# Altium schematic sheet 20 PCIe.SchDoc
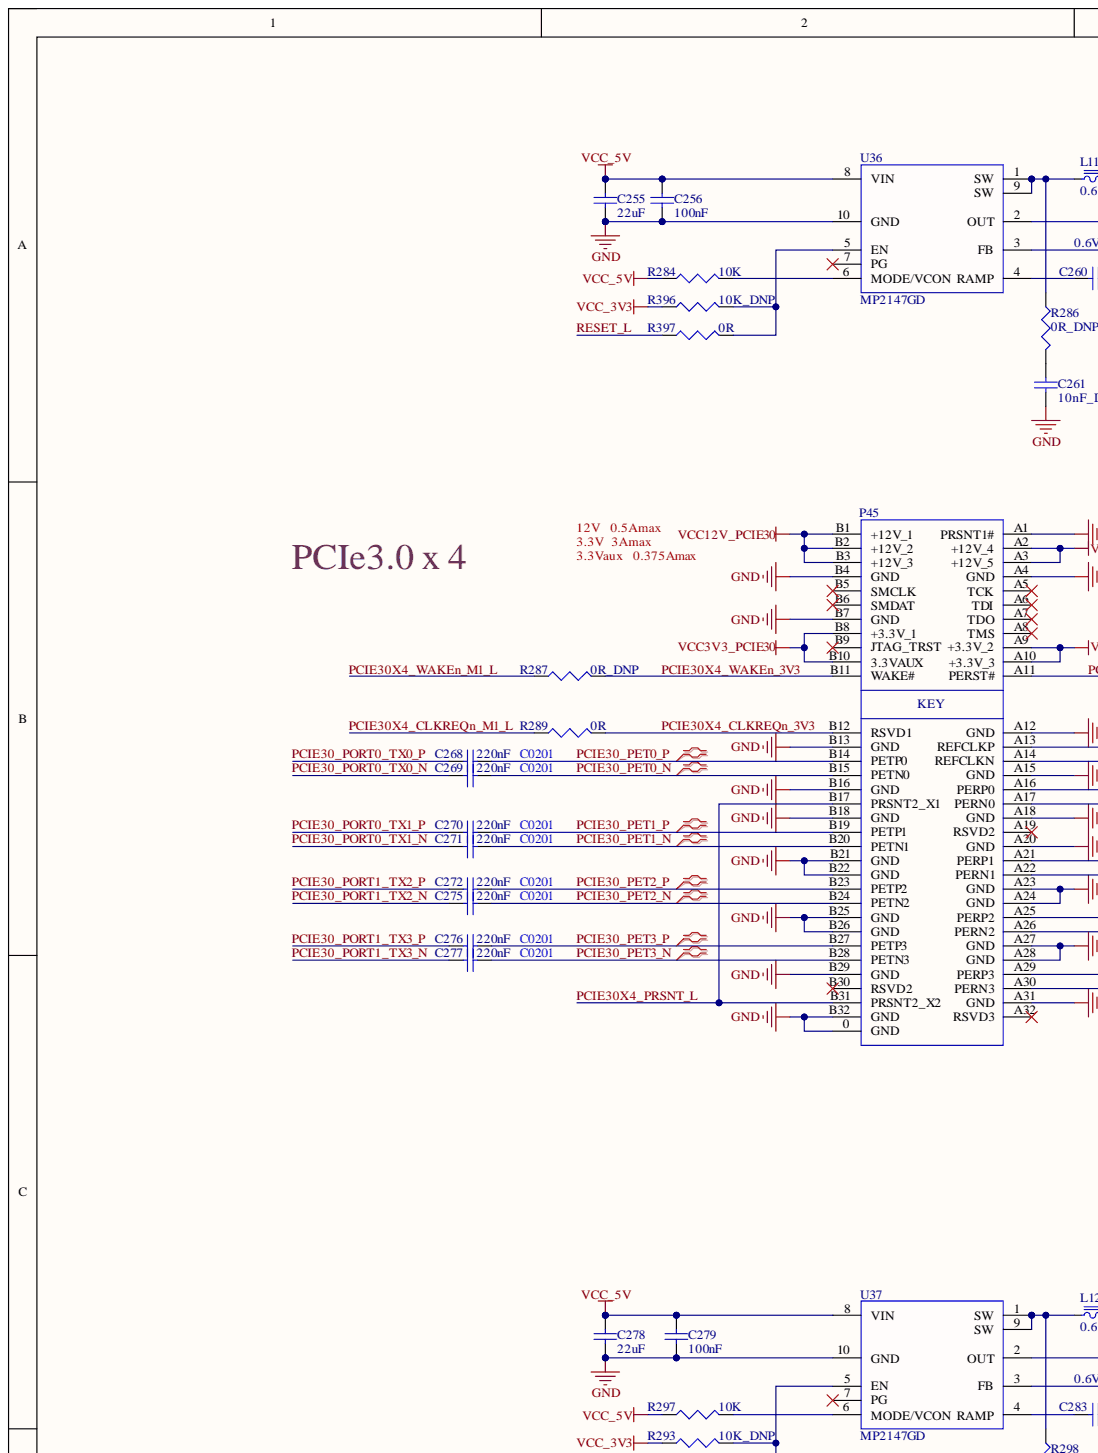
[diagram p0: 20 PCIe.SchDoc, top left]
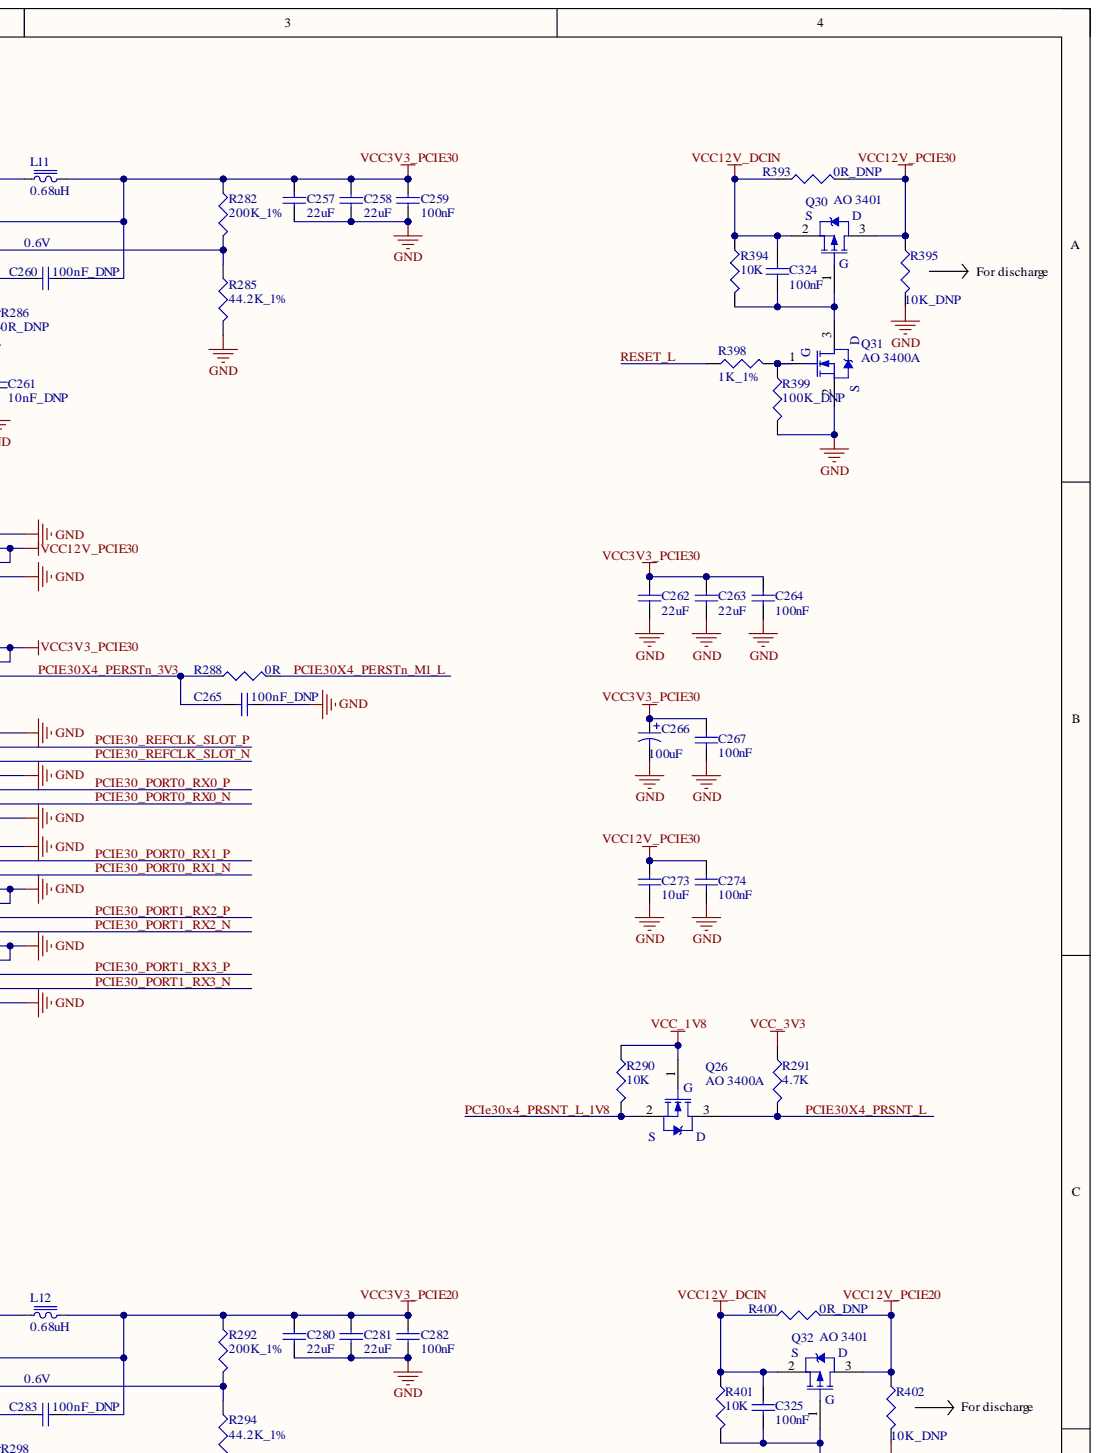
[diagram p1: 20 PCIe.SchDoc, top right]
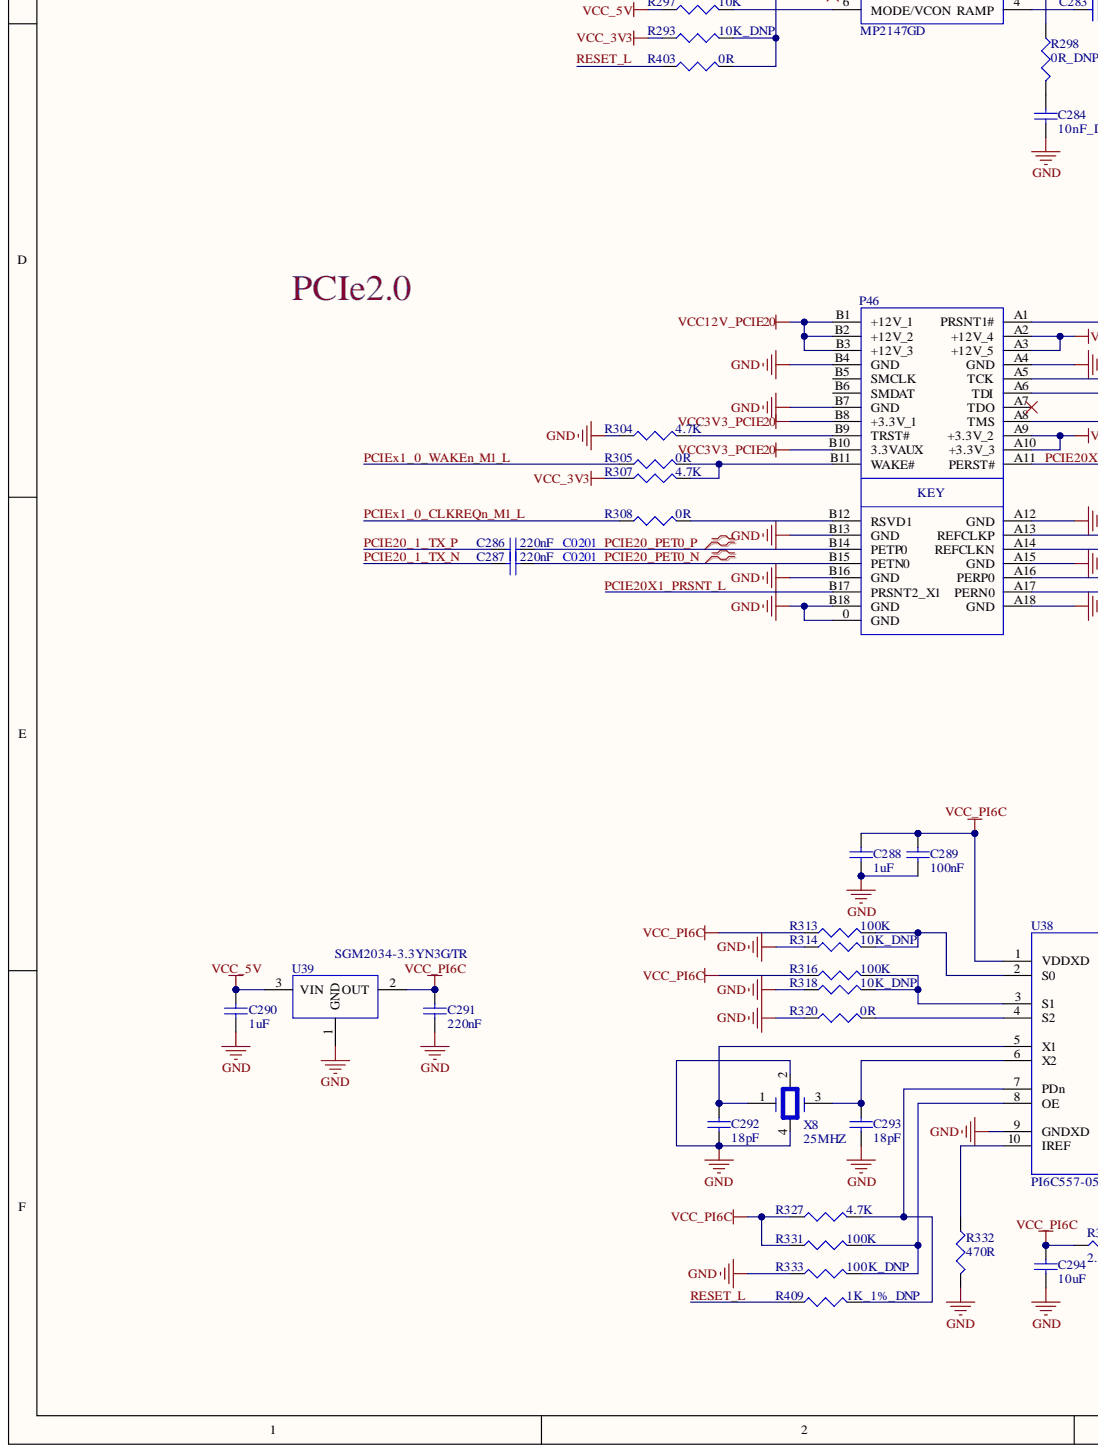
[diagram p2: 20 PCIe.SchDoc, bottom left]
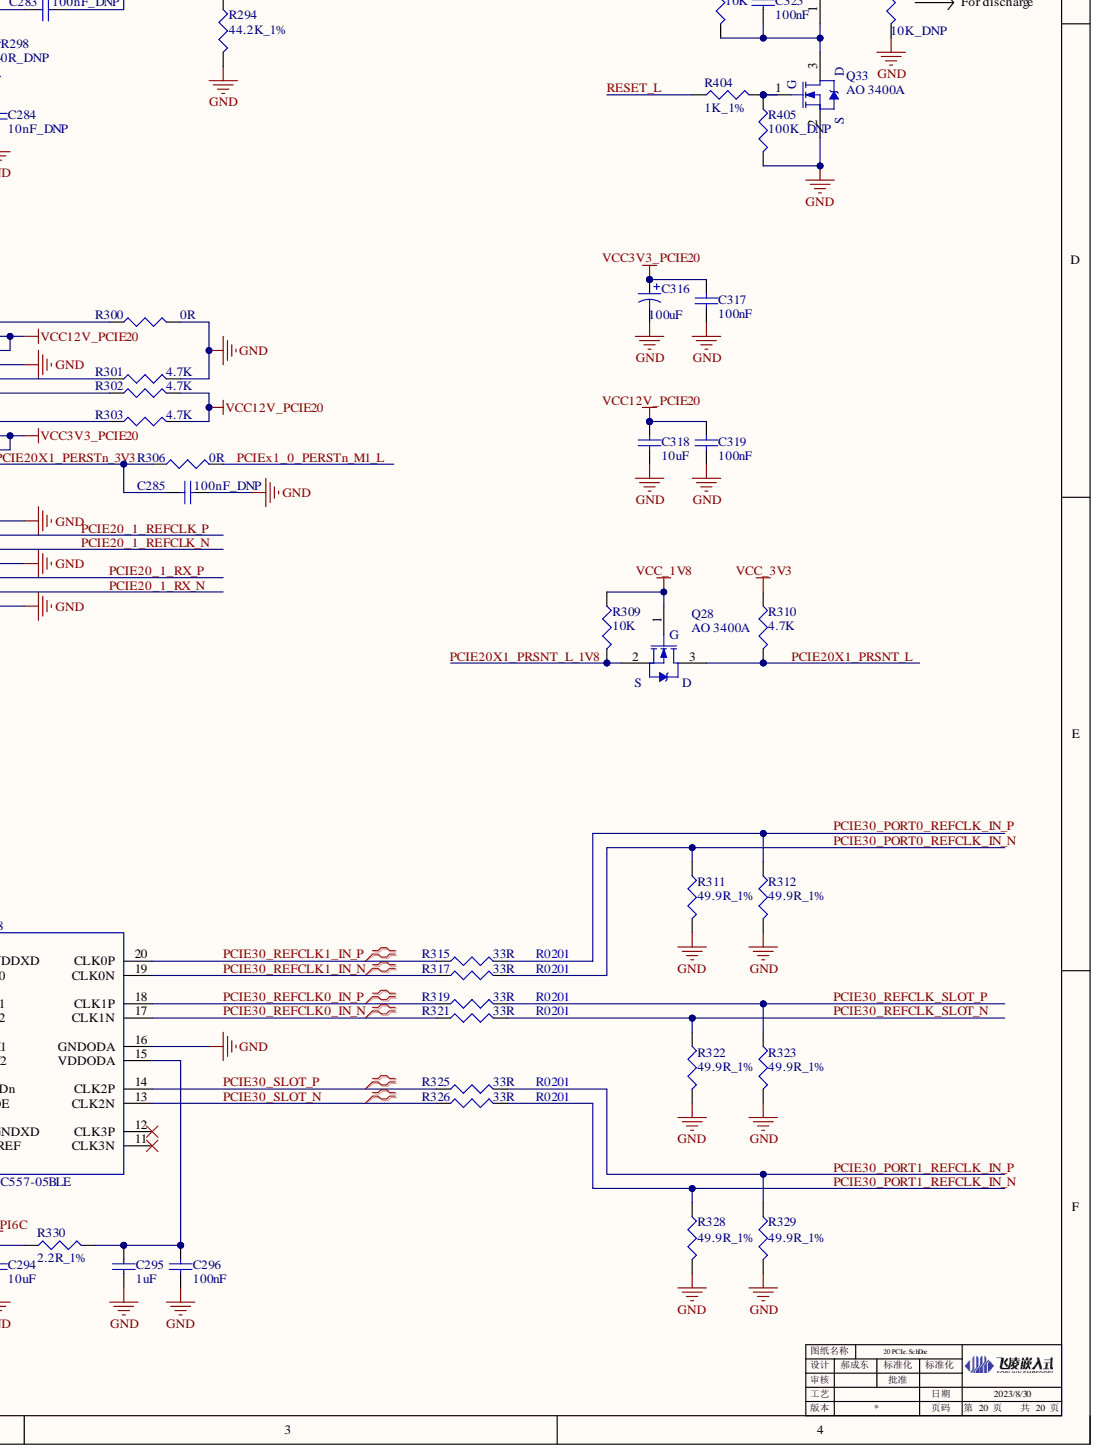
[diagram p3: 20 PCIe.SchDoc, bottom right]

## Parts
C255  22uF  [0805C]
C256  100nF  [0402C]
C257  22uF  [0805C]
C258  22uF  [0805C]
C259  100nF  [0402C]
C260  100nF_DNP  [0402C]
C261  10nF_DNP  [0402C]
C262  22uF  [0603C]
C263  22uF  [0603C]
C264  100nF  [0402C]
C265  100nF_DNP  [0402C]
C266  100uF  [CAP SMT_6.3x7.7]
C267  100nF  [0402C]
C268  220nF  [0201C]
C269  220nF  [0201C]
C270  220nF  [0201C]
C271  220nF  [0201C]
C272  220nF  [0201C]
C273  10uF  [1206C]
C274  100nF  [0402C]
C275  220nF  [0201C]
C276  220nF  [0201C]
C277  220nF  [0201C]
C278  22uF  [0805C]
C279  100nF  [0402C]
C280  22uF  [0805C]
C281  22uF  [0805C]
C282  100nF  [0402C]
C283  100nF_DNP  [0402C]
C284  10nF_DNP  [0402C]
C285  100nF_DNP  [0402C]
C286  220nF  [0201C]
C287  220nF  [0201C]
C288  1uF  [0402C]
C289  100nF  [0402C]
C290  1uF  [0402C]
C291  220nF  [0402C]
C292  18pF  [0402C]
C293  18pF  [0402C]
C294  10uF  [0402C]
C295  1uF  [0402C]
C296  100nF  [0402C]
C316  100uF  [CAP SMT_6.3x7.7]
C317  100nF  [0402C]
C318  10uF  [1206C]
C319  100nF  [0402C]
C324  100nF  [0402C]
C325  100nF  [0402C]
L11  0.68uH  [WPN4020H]
L12  0.68uH  [WPN4020H]
P45  (no value)  [PCIE_X4_SMT]
P46  (no value)  [PCIE_X1_SMT]
Q26  AO 3400A  [SOT_233_W]
Q28  AO 3400A  [SOT_233_W]
Q30  AO 3401  [SOT_233_W]
Q31  AO 3400A  [SOT_233_W]
Q32  AO 3401  [SOT_233_W]
Q33  AO 3400A  [SOT_233_W]
R282  200K_1%  [0402R]
R284  10K  [0402R]
R285  44.2K_1%  [0402R]
R286  0R_DNP  [0402R]
R287  0R_DNP  [0402R]
R288  0R  [0402R]
R289  0R  [0402R]
R290  10K  [0402R]
R291  4.7K  [0402R]
R292  200K_1%  [0402R]
R293  10K_DNP  [0402R]
R294  44.2K_1%  [0402R]
R297  10K  [0402R]
R298  0R_DNP  [0402R]
R300  0R  [0402R]
R301  4.7K  [0402R]
R302  4.7K  [0402R]
R303  4.7K  [0402R]
R304  4.7K  [0402R]
R305  0R  [0402R]
R306  0R  [0402R]
R307  4.7K  [0402R]
R308  0R  [0402R]
R309  10K  [0402R]
R310  4.7K  [0402R]
R311  49.9R_1%  [0201R]
R312  49.9R_1%  [0201R]
R313  100K  [0402R]
R314  10K_DNP  [0402R]
R315  33R  [0201R]
R316  100K  [0402R]
R317  33R  [0201R]
R318  10K_DNP  [0402R]
R319  33R  [0201R]
R320  0R  [0402R]
R321  33R  [0201R]
R322  49.9R_1%  [0201R]
R323  49.9R_1%  [0201R]
R325  33R  [0201R]
R326  33R  [0201R]
R327  4.7K  [0402R]
R328  49.9R_1%  [0201R]
R329  49.9R_1%  [0201R]
R330  2.2R_1%  [0402R]
R331  100K  [0402R]
R332  470R  [0402R]
R333  100K_DNP  [0402R]
R393  0R_DNP  [0402R]
R394  10K  [0402R]
R395  10K_DNP  [0402R]
R396  10K_DNP  [0402R]
R397  0R  [0402R]
R398  1K_1%  [0402R]
R399  100K_DNP  [0402R]
R400  0R_DNP  [0402R]
R401  10K  [0402R]
R402  10K_DNP  [0402R]
R403  0R  [0402R]
R404  1K_1%  [0402R]
R405  100K_DNP  [0402R]
R409  1K_1%_DNP  [0402R]
U36  MP2147GD  [QFN_2*3]
U37  MP2147GD  [QFN_2*3]
U38  PI6C557-05BLE  [TSSOP_20]
U39  SGM2034-3.3YN3G/TR  [SOT_233_N]
X8  25MHZ  [Crystals_3225]

## Nets
GND: C255.1 C256.1 C257.1 C258.1 C259.1 C261.1 C262.1 C263.1 C264.1 C265.2 C266.2 C267.1 C273.2 C274.1 C278.1 C279.1 C280.1 C281.1 C282.1 C284.1 C285.2 C288.1 C289.1 C290.1 C291.1 C292.1 C293.1 C294.1 C295.1 C296.1 C316.2 C317.1 C318.2 C319.1 P45.0(GND) P45.A1(PRSNT1#) P45.A4(GND) P45.A12(GND) P45.A15(GND) P45.A18(GND) P45.A20(GND) P45.A23(GND) P45.A24(GND) P45.A27(GND) P45.A28(GND) P45.A31(GND) P45.B4(GND) P45.B7(GND) P45.B13(GND) P45.B16(GND) P45.B18(GND) P45.B21(GND) P45.B22(GND) P45.B25(GND) P45.B26(GND) P45.B29(GND) P45.B32(GND) P46.0(GND) P46.A4(GND) P46.A12(GND) P46.A15(GND) P46.A18(GND) P46.B4(GND) P46.B7(GND) P46.B13(GND) P46.B16(GND) P46.B18(GND) Q31.2 Q33.2 R285.2 R294.2 R300.2 R301.2 R304.1 R311.2 R312.2 R314.1 R318.1 R320.1 R322.2 R323.2 R328.2 R329.2 R332.2 R333.1 R395.2 R399.2 R402.2 R405.2 U36.10(GND) U37.10(GND) U38.9(GNDXD) U38.16(GNDODA) U39.1 X8.2 X8.4
VCC3V3_PCIE20: C280.2 C281.2 C282.2 C283.1 C316.1 C317.2 L12.2 P46.A9(+3.3V_2) P46.A10(+3.3V_3) P46.B8(+3.3V_1) P46.B10(3.3VAUX) R292.1 U37.2(OUT)
VCC3V3_PCIE30: C257.2 C258.2 C259.2 C260.1 C262.2 C263.2 C264.2 C266.1 C267.2 L11.2 P45.A9(+3.3V_2) P45.A10(+3.3V_3) P45.B8(+3.3V_1) P45.B10(3.3VAUX) R282.1 U36.2(OUT)
VCC12V_DCIN: C324.2 C325.2 Q30.2 Q32.2 R393.1 R394.1 R400.1 R401.1
VCC12V_PCIE20: C318.1 C319.2 P46.A2(+12V_4) P46.A3(+12V_5) P46.B1(+12V_1) P46.B2(+12V_2) P46.B3(+12V_3) Q32.3 R302.2 R303.2 R400.2 R402.1
VCC12V_PCIE30: C273.1 C274.2 P45.A2(+12V_4) P45.A3(+12V_5) P45.B1(+12V_1) P45.B2(+12V_2) P45.B3(+12V_3) Q30.3 R393.2 R395.1
VCC_1V8: Q26.1 Q28.1 R290.1 R309.1
VCC_3V3: R291.1 R293.1 R307.1 R310.1 R396.1
VCC_5V: C255.2 C256.2 C278.2 C279.2 C290.2 R284.1 R297.1 U36.8(VIN) U37.8(VIN) U39.3
VCC_PI6C: C288.2 C289.2 C291.2 C294.2 R313.1 R316.1 R327.1 R330.1 R331.1 U38.1(VDDXD) U39.2
PCIE20_1_REFCLK_N: P46.A14(REFCLKN) Q26.2 R290.2  [also labelled PCIe30x4_PRSNT_L_1V8]
PCIE20_1_REFCLK_P: P46.A13(REFCLKP)
PCIE20_1_RX_N: P46.A17(PERN0)
PCIE20_1_RX_P: P46.A16(PERP0)
PCIE20_1_TX_N: C287.1
PCIE20_1_TX_P: C286.1
PCIE20_PET0_N: C287.2 P46.B15(PETN0)
PCIE20_PET0_P: C286.2 P46.B14(PETP0)
PCIE20X1_PERSTn_3V3: C285.1 P46.A11(PERST#) R306.1
PCIE20X1_PRSNT_L: P46.B17(PRSNT2_X1) Q28.3 R310.2
PCIE20X1_PRSNT_L_1V8: Q28.2 R309.2
PCIE30_PET0_N: C269.2 P45.B15(PETN0)
PCIE30_PET0_P: C268.2 P45.B14(PETP0)
PCIE30_PET1_N: C271.2 P45.B20(PETN1)
PCIE30_PET1_P: C270.2 P45.B19(PETP1)
PCIE30_PET2_N: C275.2 P45.B24(PETN2)
PCIE30_PET2_P: C272.2 P45.B23(PETP2)
PCIE30_PET3_N: C277.2 P45.B28(PETN3)
PCIE30_PET3_P: C276.2 P45.B27(PETP3)
PCIE30_PORT0_REFCLK_IN_N: R311.1 R317.2
PCIE30_PORT0_REFCLK_IN_P: R312.1 R315.2
PCIE30_PORT0_RX0_N: P45.A17(PERN0)
PCIE30_PORT0_RX0_P: P45.A16(PERP0)
PCIE30_PORT0_RX1_N: P45.A22(PERN1)
PCIE30_PORT0_RX1_P: P45.A21(PERP1)
PCIE30_PORT0_TX0_N: C269.1
PCIE30_PORT0_TX0_P: C268.1
PCIE30_PORT0_TX1_N: C271.1
PCIE30_PORT0_TX1_P: C270.1
PCIE30_PORT1_REFCLK_IN_N: R326.2 R328.1
PCIE30_PORT1_REFCLK_IN_P: R325.2 R329.1
PCIE30_PORT1_RX2_N: P45.A26(PERN2)
PCIE30_PORT1_RX2_P: P45.A25(PERP2)
PCIE30_PORT1_RX3_N: P45.A30(PERN3)
PCIE30_PORT1_RX3_P: P45.A29(PERP3)
PCIE30_PORT1_TX2_N: C275.1
PCIE30_PORT1_TX2_P: C272.1
PCIE30_PORT1_TX3_N: C277.1
PCIE30_PORT1_TX3_P: C276.1
PCIE30_REFCLK0_IN_N: R321.1 U38.17(CLK1N)
PCIE30_REFCLK0_IN_P: R319.1 U38.18(CLK1P)
PCIE30_REFCLK1_IN_N: R317.1 U38.19(CLK0N)
PCIE30_REFCLK1_IN_P: R315.1 U38.20(CLK0P)
PCIE30_REFCLK_SLOT_N: P45.A14(REFCLKN) R321.2 R322.1
PCIE30_REFCLK_SLOT_P: P45.A13(REFCLKP) R319.2 R323.1
PCIE30_SLOT_N: R326.1 U38.13(CLK2N)
PCIE30_SLOT_P: R325.1 U38.14(CLK2P)
PCIE30X4_CLKREQn_3V3: P45.B12(RSVD1) R289.2
PCIE30X4_CLKREQn_M1_L: R289.1
PCIE30X4_PERSTn_3V3: C265.1 P45.A11(PERST#) R288.1
PCIE30X4_PERSTn_M1_L: R288.2
PCIE30X4_PRSNT_L: P45.B17(PRSNT2_X1) P45.B31(PRSNT2_X2) Q26.3 R291.2
PCIE30X4_WAKEn_3V3: P45.B11(WAKE#) R287.2
PCIE30X4_WAKEn_M1_L: R287.1
PCIEx1_0_CLKREQn_M1_L: R308.1
PCIEx1_0_PERSTn_M1_L: R306.2
PCIEx1_0_WAKEn_M1_L: R305.1
RESET_L: R397.1 R398.1 R403.1 R404.1 R409.1
NetC260_2: C260.2 U36.4(RAMP)
NetC261_2: C261.2 R286.2
NetC283_2: C283.2 U37.4(RAMP)
NetC284_2: C284.2 R298.2
NetC292_2: C292.2 U38.5(X1) X8.1
NetC293_2: C293.2 U38.6(X2) X8.3
NetC295_2: C295.2 C296.2 R330.2 U38.15(VDDODA)
NetC324_1: C324.1 Q30.1 Q31.3 R394.2
NetC325_1: C325.1 Q32.1 Q33.3 R401.2
NetL11_1: L11.1 R286.1 U36.1(SW) U36.9(SW)
NetL12_1: L12.1 R298.1 U37.1(SW) U37.9(SW)
NetP46_A1: P46.A1(PRSNT1#) R300.1
NetP46_A5: P46.A5(TCK) R301.1
NetP46_A6: P46.A6(TDI) R302.1
NetP46_A8: P46.A8(TMS) R303.1
NetP46_B9: P46.B9(TRST#) R304.2
NetP46_B11: P46.B11(WAKE#) R305.2 R307.2
NetP46_B12: P46.B12(RSVD1) R308.2
NetQ31_1: Q31.1 R398.2 R399.1
NetQ33_1: Q33.1 R404.2 R405.1
NetR282_2: R282.2 R285.1 U36.3(FB)
NetR284_2: R284.2 U36.6(MODE/VCON)
NetR292_2: R292.2 R294.1 U37.3(FB)
NetR293_2: R293.2 R403.2 U37.5(EN)
NetR297_2: R297.2 U37.6(MODE/VCON)
NetR313_2: R313.2 R314.2 U38.2(S0)
NetR316_2: R316.2 R318.2 U38.3(S1)
NetR320_2: R320.2 U38.4(S2)
NetR327_2: R327.2 R409.2 U38.7(PDn)
NetR331_2: R331.2 R333.2 U38.8(OE)
NetR332_1: R332.1 U38.10(IREF)
NetR396_2: R396.2 R397.2 U36.5(EN)

Not connected: P45 A5(TCK) A6(TDI) A7(TDO) A8(TMS) A19(RSVD2) A32(RSVD3) B5(SMCLK) B6(SMDAT) B9(JTAG_TRST) B30(RSVD2); P46 A7(TDO) B5(SMCLK) B6(SMDAT); U36 7(PG); U37 7(PG); U38 11(CLK3N) 12(CLK3P)

## Library data of the parts
C255 C257 C258 C278 C280 C281 = 1110204012: Database Table Name: VALID$; 主计量单位名称: 个; 存货名称: 贴装叠层陶瓷电容; 安全库存: 6299; 序号: 747; 旧编码: 010203017; 旧规格型号: 0805 22uF 16V ±20％ X5R; 规格型号: 0805,22uF,16V,±20％,X5R; Component Kind: Standard; LatestRevisionDate: 17-Jul-2002; LatestRevisionNote: Re-released for DXP Platform.; Library Name: Database_AD Library.DbLib; PackageDescription: Radial Cap, Thru-Hole; 2 Leads; 0.3 in Pin Spacing; PackageReference: RAD-0.3; Published: 8-Jun-2000; Signal Integrity: Cap; Simulation: CAP; Value: 22uF; 存货编码: 1110204012; Publisher: Altium Limited
C256 C259 C260 C264 C265 C267 C274 C279 C282 C283 C285 C289 C296 C317 C319 C324 C325 = 1110202039: Database Table Name: VALID$; 存货名称: 贴装叠层陶瓷电容; 序号: 626; 规格型号: 0402,100nF,25V,±10％,X5R; Component Kind: Standard; LatestRevisionDate: 17-Jul-2002; LatestRevisionNote: Re-released for DXP Platform.; Library Name: Database_AD Library.DbLib; PackageDescription: Radial Cap, Thru-Hole; 2 Leads; 0.3 in Pin Spacing; PackageReference: RAD-0.3; Published: 8-Jun-2000; Signal Integrity: Cap; Simulation: CAP; 存货编码: 1110202039; Publisher: Altium Limited
  C256 C259 C264 C267 C274 C279 C282 C289 C296 C317 C319 C324 C325: Value: 100nF
  C260 C265 C283 C285: Value: 100nF_DNP
C261 C284 = 1110202014: Database Table Name: VALID$; 存货名称: 贴装叠层陶瓷电容; 序号: 585; 规格型号: 0402,10nF,50V,±10％,X7R; Component Kind: Standard; LatestRevisionDate: 17-Jul-2002; LatestRevisionNote: Re-released for DXP Platform.; Library Name: Database_AD Library.DbLib; PackageDescription: Radial Cap, Thru-Hole; 2 Leads; 0.3 in Pin Spacing; PackageReference: RAD-0.3; Published: 8-Jun-2000; Signal Integrity: Cap; Simulation: CAP; Value: 10nF_DNP; 存货编码: 1110202014; Publisher: Altium Limited
C262 C263 = 1110203040: Database Table Name: VALID$; 存货名称: 贴装叠层陶瓷电容; 序号: 675; 规格型号: 0603,22uF,6.3V,±20％,X5R; Component Kind: Standard; LatestRevisionDate: 17-Jul-2002; LatestRevisionNote: Re-released for DXP Platform.; Library Name: Database_AD Library.DbLib; PackageDescription: Radial Cap, Thru-Hole; 2 Leads; 0.3 in Pin Spacing; PackageReference: RAD-0.3; Published: 8-Jun-2000; Signal Integrity: Cap; Simulation: CAP; Value: 22uF; 存货编码: 1110203040; Publisher: Altium Limited
C266 C316 = 1110211005: Database Table Name: VALID$; 存货名称: 贴装铝电解电容; 序号: 861; 规格型号: 100uF,16V,6.3*5.9mm; Component Kind: Standard; LatestRevisionDate: 17-Jul-2002; LatestRevisionNote: Re-released for DXP Platform.; Library Name: Database_AD Library.DbLib; PackageDescription: Polarized Capacitor (Radial); 2 Leads; PackageReference: RB7.6-15; Published: 8-Jun-2000; Signal Integrity: Cap Pol; Simulation: CAP; Value: 100uF; 存货编码: 1110211005; Publisher: Altium Limited
C268-C272 C275-C277 C286 C287 = 1110201001: Database Table Name: VALID$; 存货名称: 贴装叠层陶瓷电容; 序号: 928; 规格型号: 0201,220nF,6.3V,±20％,X5R; Component Kind: Standard; LatestRevisionDate: 17-Jul-2002; LatestRevisionNote: Re-released for DXP Platform.; Library Name: Database_AD Library.DbLib; PackageDescription: Radial Cap, Thru-Hole; 2 Leads; 0.3 in Pin Spacing; PackageReference: RAD-0.3; Published: 8-Jun-2000; Signal Integrity: Cap; Simulation: CAP; Value: 220nF; 存货编码: 1110201001; Publisher: Altium Limited
C273 C318 = 1110205010: Database Table Name: VALID$; 存货名称: 贴装叠层陶瓷电容; 序号: 768; 规格型号: 1206,10uF,25V,±10％,X5R; Component Kind: Standard; LatestRevisionDate: 17-Jul-2002; LatestRevisionNote: Re-released for DXP Platform.; Library Name: Database_AD Library.DbLib; PackageDescription: Radial Cap, Thru-Hole; 2 Leads; 0.3 in Pin Spacing; PackageReference: RAD-0.3; Published: 8-Jun-2000; Signal Integrity: Cap; Simulation: CAP; Value: 10uF; 存货编码: 1110205010; Publisher: Altium Limited
C288 C290 C295 = 1110202021: Database Table Name: VALID$; 存货名称: 贴装叠层陶瓷电容; 序号: 600; 规格型号: 0402,1uF,16V,±10％,X5R; Component Kind: Standard; LatestRevisionDate: 17-Jul-2002; LatestRevisionNote: Re-released for DXP Platform.; Library Name: Database_AD Library.DbLib; PackageDescription: Radial Cap, Thru-Hole; 2 Leads; 0.3 in Pin Spacing; PackageReference: RAD-0.3; Published: 8-Jun-2000; Signal Integrity: Cap; Simulation: CAP; Value: 1uF; 存货编码: 1110202021; Publisher: Altium Limited
C291 = 1110202042: Database Table Name: VALID$; 存货名称: 贴装叠层陶瓷电容; 规格型号: 0402,220nF,25V,±10％,X5R; Component Kind: Standard; LatestRevisionDate: 17-Jul-2002; LatestRevisionNote: Re-released for DXP Platform.; Library Name: Database_AD Library.DbLib; PackageDescription: Radial Cap, Thru-Hole; 2 Leads; 0.3 in Pin Spacing; PackageReference: RAD-0.3; Published: 8-Jun-2000; Signal Integrity: Cap; Simulation: CAP; Value: 220nF; 存货编码: 1110202042; Publisher: Altium Limited
C292 C293 = 1110202008: Database Table Name: VALID$; 存货名称: 贴装叠层陶瓷电容; 序号: 578; 规格型号: 0402,18pF,50V,±5％,NPO; Component Kind: Standard; LatestRevisionDate: 17-Jul-2002; LatestRevisionNote: Re-released for DXP Platform.; Library Name: Database_AD Library.DbLib; PackageDescription: Radial Cap, Thru-Hole; 2 Leads; 0.3 in Pin Spacing; PackageReference: RAD-0.3; Published: 8-Jun-2000; Signal Integrity: Cap; Simulation: CAP; Value: 18pF; 存货编码: 1110202008; Publisher: Altium Limited
C294 = 1110202033: Database Table Name: VALID$; 存货名称: 贴装叠层陶瓷电容; 序号: 619; 规格型号: 0402,10uF,6.3V,±20％,X5R; Component Kind: Standard; LatestRevisionDate: 17-Jul-2002; LatestRevisionNote: Re-released for DXP Platform.; Library Name: Database_AD Library.DbLib; PackageDescription: Radial Cap, Thru-Hole; 2 Leads; 0.3 in Pin Spacing; PackageReference: RAD-0.3; Published: 8-Jun-2000; Signal Integrity: Cap; Simulation: CAP; Value: 10uF; 存货编码: 1110202033; Publisher: Altium Limited
L11 L12 = 1110301092: Database Table Name: VALID$; 存货名称: 绕线电感; 描述栏信息: Sunlord; 规格型号: 0.68uH±20%,4*4*2mm,11.1A/7.4A,平行焊盘,非屏蔽,WPN4020HR68MT; Component Kind: Standard; LatestRevisionDate: 17-Jul-2002; LatestRevisionNote: Re-released for DXP Platform.; Library Name: Database_AD Library.DbLib; PackageDescription: Axial Device, Thru-Hole; 2 Leads; 0.9 in Pin Spacing; PackageReference: AXIAL-0.9; Published: 24-Mar-1999; Signal Integrity: Inductor Iron; Simulation: INDUCTOR; Value: 0.68uH; 存货编码: 1110301092; Publisher: Altium Limited
P45 = PCIE X4: Component Kind: Standard; Library Name: Forlinx Schlib.SchLib
P46 = PCIE X1: Component Kind: Standard; Library Name: Forlinx Schlib.SchLib
Q26 Q28 Q31 Q33 = 1110505027: Database Table Name: VALID$; Note: Pin 2 is electrically connected to pin 4.; 存货名称: 场效应管; 描述栏信息: 替换1110505011; 规格型号: AO3400A,SOT23,N沟道; Component Kind: Standard; DatasheetVersion: PD-9.814; LatestRevisionDate: 17-Jul-2002; LatestRevisionNote: Re-released for DXP Platform.; Library Name: Database_AD Library.DbLib; PackageDescription: TO, Thru-Hole, Vertical, Heatsink Mounted; 3 In-Line Leads; Pitch 2.54 mm; PackageReference: TO-220AB; PackageVersion: Feb-1997; Published: 8-Jun-2000; Simulation: IRF1010; VALUE: AO 3400A; 存货编码: 1110505027; Publisher: Altium Limited
Q30 Q32 = 1110505019: Database Table Name: VALID$; Note: Pin 2 is electrically connected to pin 4.; 存货名称: 场效应管; 序号: 2675; 规格型号: AO3401,SOT-23-3,P沟道; Component Kind: Standard; ComponentLink1Description: Manufacturer Link; ComponentLink1URL: http://www.irf.com/; DatasheetVersion: PD-9.814; LatestRevisionDate: 17-Jul-2002; LatestRevisionNote: Re-released for DXP Platform.; Library Name: Database_AD Library.DbLib; PackageDescription: TO, Thru-Hole, Vertical, Heatsink Mounted; 3 In-Line Leads; Pitch 2.54 mm; PackageReference: TO-220AB; PackageVersion: Feb-1997; Published: 8-Jun-2000; Simulation: IRF1010; VALUE: AO 3401; 存货编码: 1110505019; Publisher: Altium Limited
R282 R292 = 1110102049: Database Table Name: VALID$; 存货名称: 贴装电阻; 序号: 63; 规格型号: 0402,200K,±1％; Component Kind: Standard; Library Name: Database_AD Library.DbLib; Signal Integrity: Res; Simulation: RESISTOR; Value: 200K_1%; 存货编码: 1110102049
R284 R290 R293 R297 R309 R314 R318 R394-R396 R401 R402 = 1110102007: Database Table Name: VALID$; 存货名称: 贴装电阻; 序号: 17; 规格型号: 0402,10K,±5％; Component Kind: Standard; Library Name: Database_AD Library.DbLib; Signal Integrity: Res; Simulation: RESISTOR; 存货编码: 1110102007
  R284 R290 R297 R309 R394 R401: Value: 10K
  R293 R314 R318 R395 R396 R402: Value: 10K_DNP
R285 R294 = 1110102127: Database Table Name: VALID$; 存货名称: 贴装电阻; 序号: 164; 规格型号: 0402,44.2K,±1％; Component Kind: Standard; Library Name: Database_AD Library.DbLib; Signal Integrity: Res; Simulation: RESISTOR; Value: 44.2K_1%; 存货编码: 1110102127
R286-R289 R298 R300 R305 R306 R308 R320 R393 R397 R400 R403 = 1110102001: Database Table Name: VALID$; 存货名称: 贴装电阻; 序号: 10; 规格型号: 0402,0Ω,±5％; Component Kind: Standard; Library Name: Database_AD Library.DbLib; Signal Integrity: Res; Simulation: RESISTOR; 存货编码: 1110102001
  R286 R287 R298 R393 R400: Value: 0R_DNP
  R288 R289 R300 R305 R306 R308 R320 R397 R403: Value: 0R
R291 R301-R304 R307 R310 R327 = 1110102003: Database Table Name: VALID$; 存货名称: 贴装电阻; 序号: 12; 规格型号: 0402,4.7K,±5％; Component Kind: Standard; Library Name: Database_AD Library.DbLib; Signal Integrity: Res; Simulation: RESISTOR; Value: 4.7K; 存货编码: 1110102003
R311 R312 R322 R323 R328 R329 = 1110101027: Database Table Name: VALID$; 存货名称: 贴装电阻; 规格型号: 0201,49.9Ω,±1％; Component Kind: Standard; Library Name: Database_AD Library.DbLib; Signal Integrity: Res; Simulation: RESISTOR; Value: 49.9R_1%; 存货编码: 1110101027
R313 R316 R331 R333 R399 R405 = 1110102004: Database Table Name: VALID$; 存货名称: 贴装电阻; 序号: 14; 规格型号: 0402,100K,±5％; Component Kind: Standard; Library Name: Database_AD Library.DbLib; Signal Integrity: Res; Simulation: RESISTOR; 存货编码: 1110102004
  R313 R316 R331: Value: 100K
  R333 R399 R405: Value: 100K_DNP
R315 R317 R319 R321 R325 R326 = 1110101001: Database Table Name: VALID$; 存货名称: 贴装电阻; 存货编码: 新增; 序号: 556; 规格型号: 0201,0Ω,±5%; Component Kind: Standard; Library Name: Database_AD Library.DbLib; Signal Integrity: Res; Simulation: RESISTOR; Value: 33R
R330 = 1110102195: Database Table Name: VALID$; 存货名称: 贴装电阻; 规格型号: 0402,2.2Ω,±1%; Component Kind: Standard; Library Name: Database_AD Library.DbLib; Signal Integrity: Res; Simulation: RESISTOR; Value: 2.2R_1%; 存货编码: 1110102195
R332 = 1110102035: Database Table Name: VALID$; 存货名称: 贴装电阻; 序号: 48; 规格型号: 0402,470Ω,±5％; Component Kind: Standard; Library Name: Database_AD Library.DbLib; Signal Integrity: Res; Simulation: RESISTOR; Value: 470R; 存货编码: 1110102035
R398 R404 R409 = 1110102011: Database Table Name: VALID$; 存货名称: 贴装电阻; 序号: 21; 规格型号: 0402,1K,±1％; Component Kind: Standard; Library Name: Database_AD Library.DbLib; Signal Integrity: Res; Simulation: RESISTOR; 存货编码: 1110102011
  R398 R404: Value: 1K_1%
  R409: Value: 1K_1%_DNP
U36 U37 = 1110404132: Database Table Name: VALID$; 存货名称: 电源; 规格型号: MP2147GD,QFN2×3mm; Component Kind: Standard; Library Name: Database_AD Library.DbLib; Value: MP2147GD; 存货编码: 1110404132
U39 = 1110404126: Database Table Name: VALID$; 存货名称: 电源; 描述栏信息: 替代1110404068，备件为1110404151; 规格型号: SGM2034-3.3YN3G/TR,SOT-23-3; Component Kind: Standard; Library Name: Database_AD Library.DbLib; Value: SGM2034-3.3YN3G/TR; 存货编码: 1110404126
X8 = 1110601039: Database Table Name: VALID$; 存货名称: 贴装晶振; 序号: 3402; 规格型号: 无源,25MHZ,4P,3.2*2.5mm,±10ppm,18pF,TSX-3225; Component Kind: Standard; Library Name: Database_AD Library.DbLib; Value: 25MHZ; 存货编码: 1110601039
U38 = PI6C557-05BLE: Component Kind: Standard; Library Name: OK3588-C V1.0.SchLib; value: PI6C557-05BLE
